# Altium schematic sheet scheme.SchDoc(scheme)
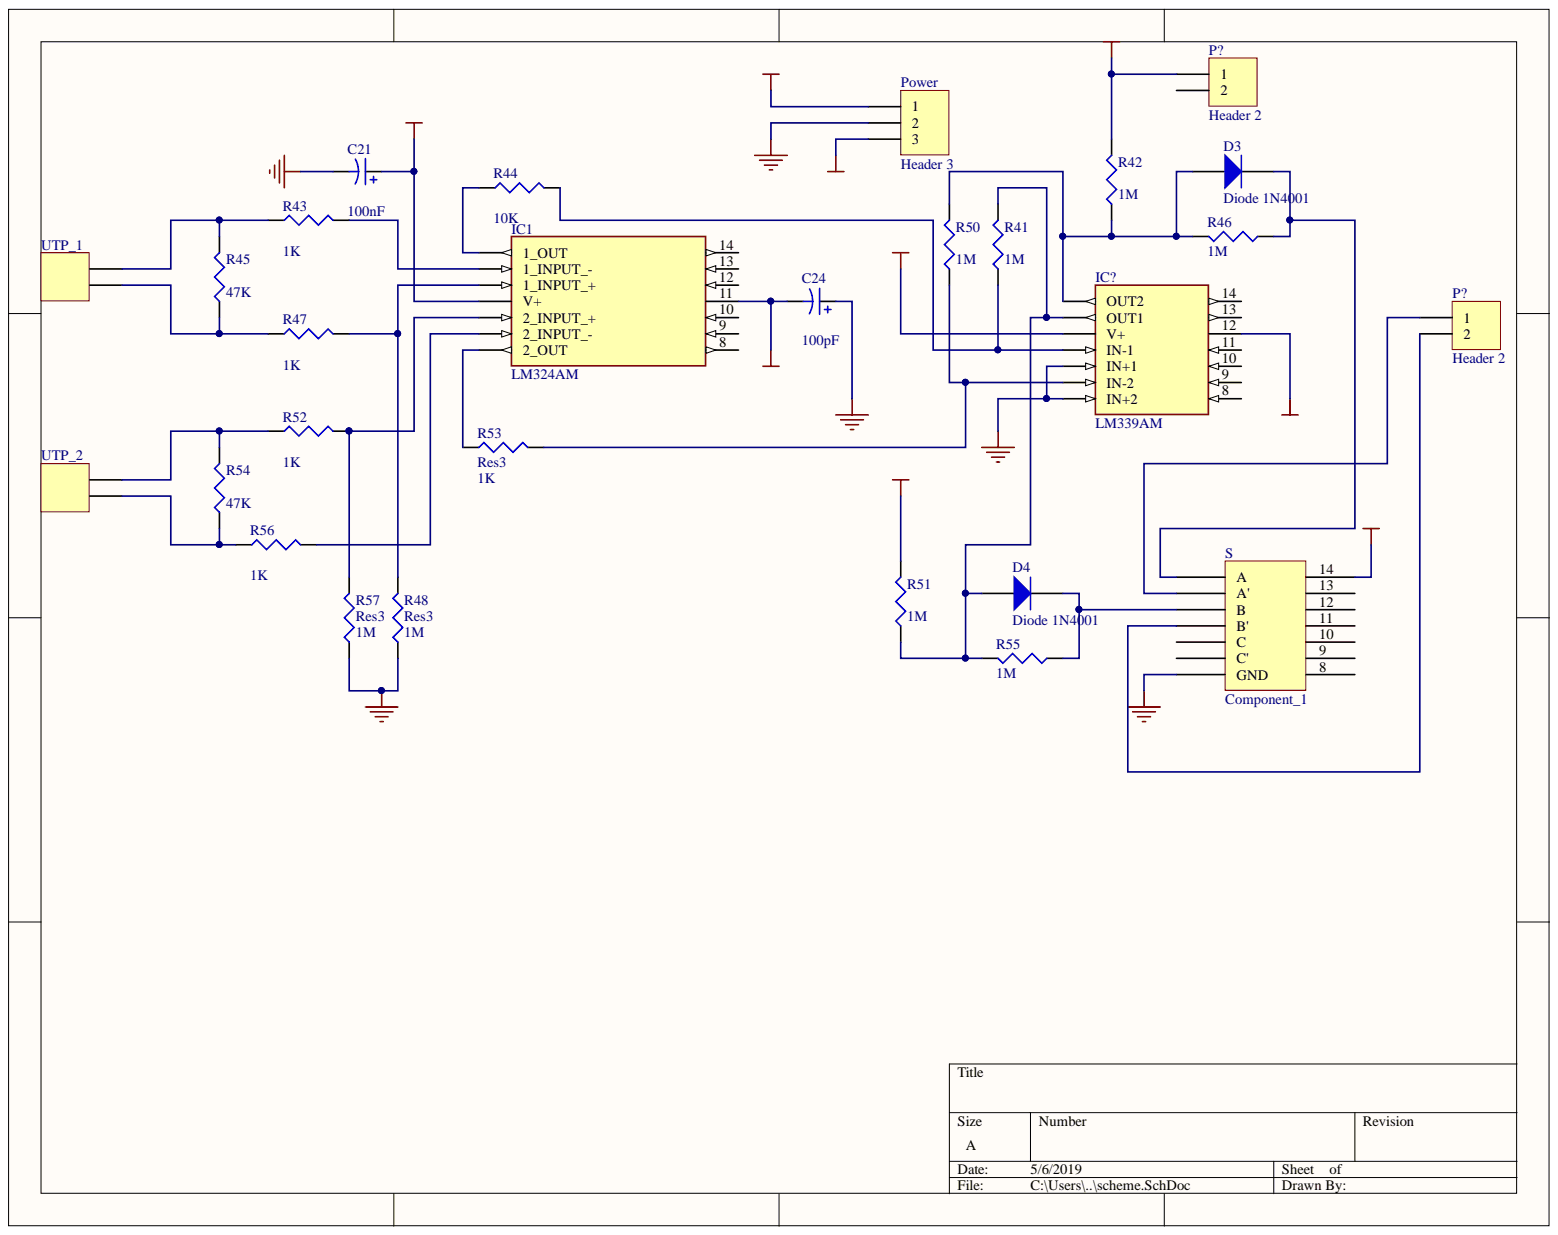
[diagram p0: scheme.SchDoc]

## Parts
C21  value 100nF  [C1206]  (hidden comment: Cap Pol3)
C24  value 100pF  [C1206]  (hidden comment: Cap Pol3)
D3  Diode 1N4001  [SOT23_N]
D4  Diode 1N4001  [SOT23_N]
IC1  LM324AM  [SOIC127P600X175-14N]
IC?  LM339AM  [SOIC127P600X175-14N]
P?  Header 2  [HDR1X2]
P?  Header 2  [HDR1X2]
Power  Header 3  [HDR1X3]
R41  value 1M  [C1206]  (hidden comment: Res3)
R42  value 1M  [C1206]  (hidden comment: Res3)
R43  value 1K  [C1206]  (hidden comment: Res3)
R44  value 10K  [C1206]  (hidden comment: Res3)
R45  value 47K  [C1206]  (hidden comment: Res3)
R46  value 1M  [C1206]  (hidden comment: Res3)
R47  value 1K  [C1206]  (hidden comment: Res3)
R48  Res3  value 1M  [C1206]
R50  value 1M  [C1206]  (hidden comment: Res3)
R51  value 1M  [C1206]  (hidden comment: Res3)
R52  value 1K  [C1206]  (hidden comment: Res3)
R53  Res3  value 1K  [C1206]
R54  value 47K  [C1206]  (hidden comment: Res3)
R55  value 1M  [C1206]  (hidden comment: Res3)
R56  value 1K  [C1206]  (hidden comment: Res3)
R57  Res3  value 1M  [C1206]
S  Component_1  [SOIC127P600X175-14N]
UTP_1  Header 2  [HDR1X2]  (value not drawn)
UTP_2  Header 2  [HDR1X2]  (value not drawn)

## Nets
GND: C21.2 C24.1 IC?.5(IN+1) IC?.7(IN+2) Power.2 R48.1 R57.1 S.7(GND)
VCC +5V: P?.1 R42.2 R51.2
VCC +15V: C21.1 IC1.4(V+) IC?.3(V+) Power.1 S.14
VCC -15: C24.2 IC1.11 IC?.12 Power.3
NetD3_1: D3.1 IC?.1(OUT2) R42.1 R46.2 R50.2
NetD3_2: D3.2 R46.1 S.1(A)
NetD4_1: D4.1 IC?.2(OUT1) R41.2 R51.1 R55.2
NetD4_2: D4.2 R55.1 S.3(B)
NetIC1_1: IC1.1(1_OUT) R44.1
NetIC1_2: IC1.2(1_INPUT_-) R43.2
NetIC1_3: IC1.3(1_INPUT_+) R47.2 R48.2
NetIC1_5: IC1.5(2_INPUT_+) R52.2 R57.2
NetIC1_6: IC1.6(2_INPUT_-) R56.2
NetIC1_7: IC1.7(2_OUT) R53.1
NetIC?_4: IC?.4(IN-1) R41.1 R44.2
NetIC?_6: IC?.6(IN-2) R50.1 R53.2
NetP?_1: P?.1 S.2(A')
NetP?_2: P?.2 S.4(B')
NetR43_1: R43.1 R45.2 UTP_1.2
NetR45_1: R45.1 R47.1 UTP_1.1
NetR52_1: R52.1 R54.2 UTP_2.2
NetR54_1: R54.1 R56.1 UTP_2.1

Not connected: IC1 8 9 10 12 13 14; IC? 8 9 10 11 13 14; S 5(C) 6(C') 8 9 10 11 12 13

## Library data of the parts
C21 = Cap Pol3: Polarized Capacitor (Surface Mount); Value: 100nF; Simulation: CAP; Signal Integrity: Cap Pol; Published: 8-Jun-2000; PackageReference: C0805; PackageDescription: Chip Capacitor; Library Name: Miscellaneous Devices.IntLib; LatestRevisionNote: IPC-7351 Footprint Added.; LatestRevisionDate: 29-May-2009; Component Kind: Standard; Publisher: Altium Limited
C24 = Cap Pol3: Polarized Capacitor (Surface Mount); Simulation: CAP; Signal Integrity: Cap Pol; Published: 8-Jun-2000; PackageReference: C0805; PackageDescription: Chip Capacitor; Library Name: Miscellaneous Devices.IntLib; LatestRevisionNote: IPC-7351 Footprint Added.; LatestRevisionDate: 29-May-2009; Component Kind: Standard; Publisher: Altium Limited
D3 D4 = Diode 1N4001: 1 Amp General Purpose Rectifier; Simulation: 1N4001; Signal Integrity: 1N4001; Published: 8-Jun-2000; PackageVersion: Aug-1999; PackageReference: DO-41; PackageDescription: Diode, Axial; Body 5.2 x 2.7 mm (LxDia. max), Lead Dia. 0.86 mm (max); Library Name: Miscellaneous Devices.IntLib; LatestRevisionNote: Re-released for DXP Platform.; LatestRevisionDate: 17-Jul-2002; DatasheetVersion: 1998; ComponentLink2URL: http://www.fairchildsemi.com/ds/1N%2F1N4001.pdf; ComponentLink2Description: Datasheet; ComponentLink1URL: http://www.fairchildsemi.com/; ComponentLink1Description: Manufacturer Link; Component Kind: Standard; Code_JEDEC: DO-204-AL; Publisher: Altium Limited
IC1 = LM324AM: Integrated Circuit; Manufacturer_Name: Texas Instruments; RS Part Number: 4713839; Mouser Price/Stock: https://www.mouser.com/Search/Refine.aspx?Keyword=926-LM324AM; Mouser Part Number: 926-LM324AM; Manufacturer_Part_Number: LM324AM; Height: 1.75mm; Datasheet Link: http://www.ti.com/lit/ds/symlink/lm324-n.pdf; Component Kind: Standard
IC? = LM339AM: Integrated Circuit; Manufacturer_Name: Texas Instruments; RS Part Number: 6364697; Mouser Price/Stock: https://www.mouser.com/Search/Refine.aspx?Keyword=926-LM339AM; Mouser Part Number: 926-LM339AM; Manufacturer_Part_Number: LM339AM; Height: 1.75mm; Datasheet Link: http://www.ti.com/lit/ds/symlink/lm339-n.pdf; Component Kind: Standard
P? P? UTP_1 UTP_2 = Header 2: Header, 2-Pin; Signal Integrity: Connector; Library Name: Miscellaneous Connectors.IntLib; LatestRevisionNote: Re-released for DXP Platform.; LatestRevisionDate: 17-Jul-2002; Component Kind: Standard; Publisher: Altium Limited
Power = Header 3: Header, 3-Pin; Signal Integrity: Connector; Library Name: Miscellaneous Connectors.IntLib; LatestRevisionNote: Re-released for DXP Platform.; LatestRevisionDate: 17-Jul-2002; Component Kind: Standard; Publisher: Altium Limited
R41-R43 R45-R48 R50-R57 = Res3: Resistor; Simulation: RESISTOR; Signal Integrity: Res; Published: 8-Jun-2000; PackageReference: J1-0603; PackageDescription: Chip Resistor; Library Name: Miscellaneous Devices.IntLib; LatestRevisionNote: IPC-7351 Footprint Added.; LatestRevisionDate: 29-May-2009; Component Kind: Standard; Publisher: Altium Limited
R44 = Res3: Resistor; Value: 10K; Simulation: RESISTOR; Signal Integrity: Res; Published: 8-Jun-2000; PackageReference: J1-0603; PackageDescription: Chip Resistor; Library Name: Miscellaneous Devices.IntLib; LatestRevisionNote: IPC-7351 Footprint Added.; LatestRevisionDate: 29-May-2009; Component Kind: Standard; Publisher: Altium Limited
S = Component_1: Library Name: Schlib1.SchLib; Component Kind: Standard
